# Altium schematic sheet 06 USB3_LED_OSC.SchDoc
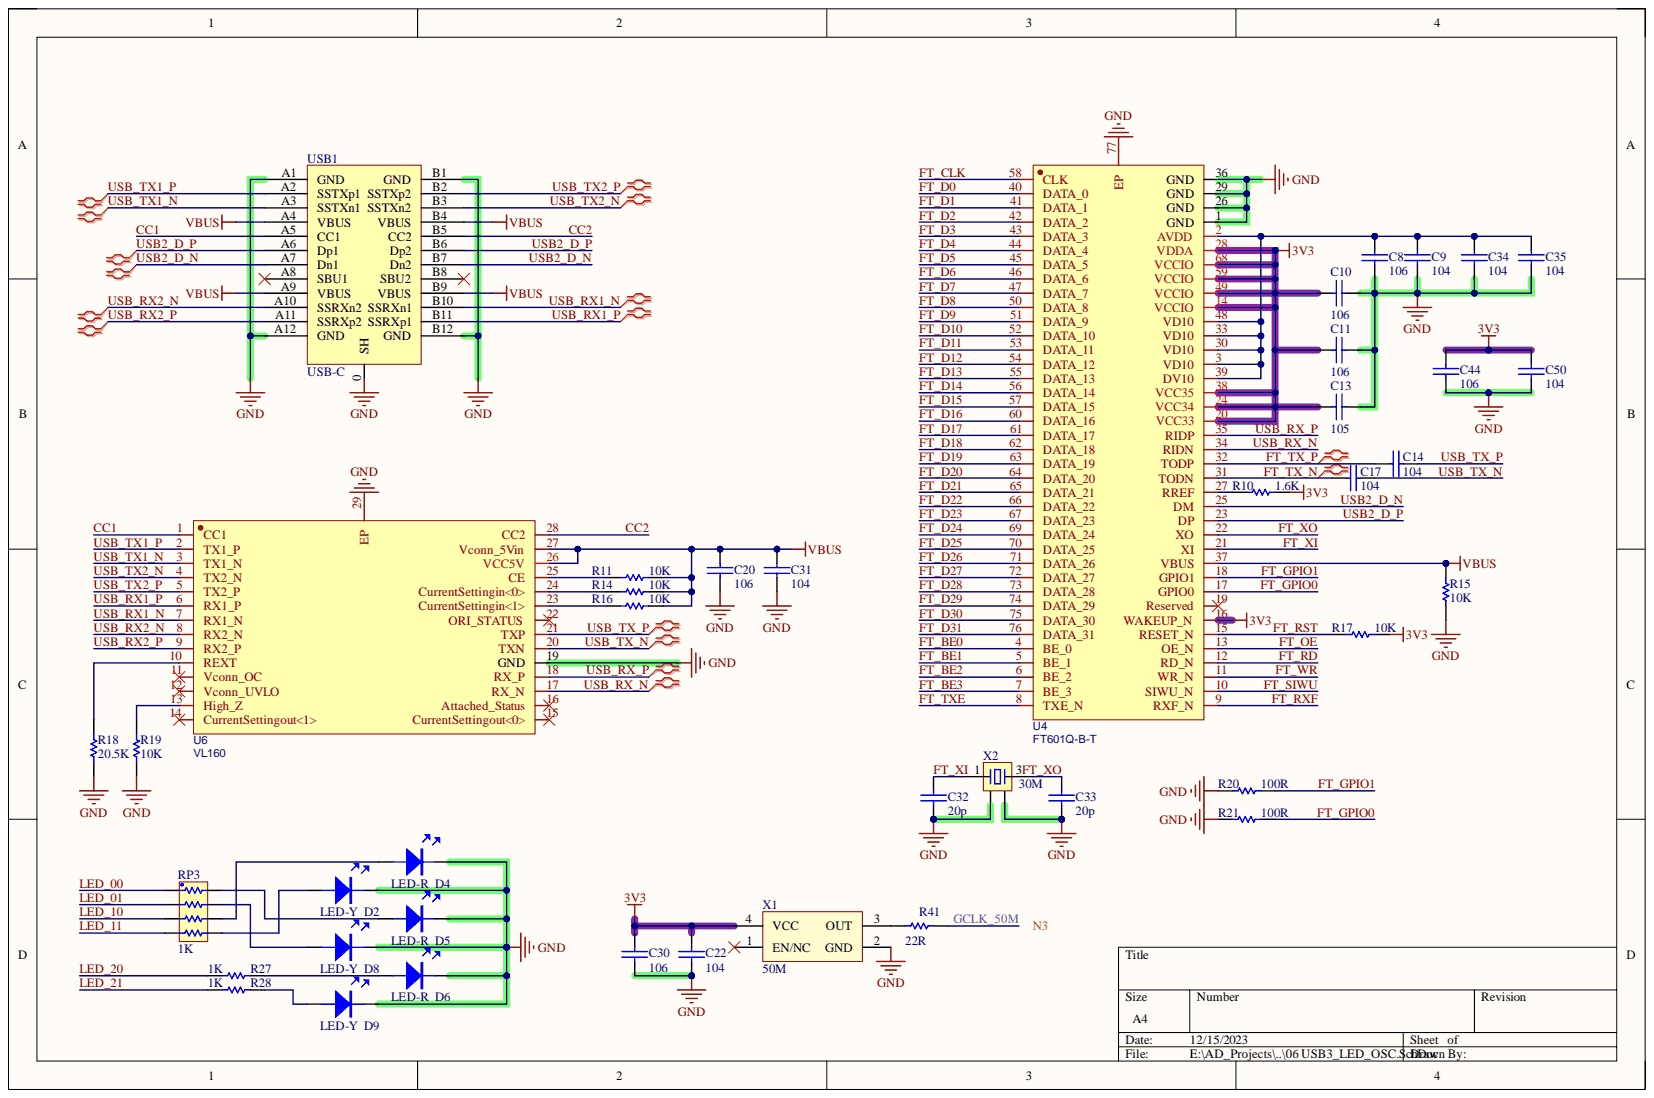
[diagram p0: 06 USB3_LED_OSC.SchDoc]

## Parts
C8  106  [0402C]
C9  104  [0402C]
C10  106  [0402C]
C11  106  [0402C]
C13  105  [0402C]
C14  104  [0402C]
C17  104  [0402C]
C20  106  [0402C]
C22  104  [0402C]
C30  106  [0402C]
C31  104  [0402C]
C32  20p  [0402C]
C33  20p  [0402C]
C34  104  [0402C]
C35  104  [0402C]
C44  106  [0402C]
C50  104  [0402C]
D2  LED-Y  [LED-0603Y]
D4  LED-R  [LED-0603W]
D5  LED-R  [LED-0603W]
D6  LED-R  [LED-0603W]
D8  LED-Y  [LED-0603Y]
D9  LED-Y  [LED-0603Y]
R10  1.6K  [0402R]
R11  10K  [0402R]
R14  10K  [0402R]
R15  10K  [0402R]
R16  10K  [0402R]
R17  10K  [0402R]
R18  20.5K  [0402R]
R19  10K  [0402R]
R20  100R  [0402R]
R21  100R  [0402R]
R27  1K  [0402R]
R28  1K  [0402R]
R41  22R  [0402R]
RP3  1K  [贴片排阻]
U4  FT601Q-B-T  [QFN-76_L9.0-W9.0-P0.40-TL-EP]
U6  VL160  [MQFN-28_L4.5-W3.5-P0.40-BL-EP]
USB1  USB-C  [USBC_24P_侧立]
X1  50M  [OSC 3225-4P]
X2  30M  [OSC 3225-4P]

## Nets
3V3: C10.2 C11.2 C13.2 C22.2 C30.2 C44.2 C50.2 R10.2 R17.2 U4.14(VCCIO) U4.16(WAKEUP_N) U4.20(VCC33) U4.24(VCC34) U4.28(VDDA) U4.38(VCC35) U4.49(VCCIO) U4.59(VCCIO) U4.68(VCCIO) X1.4(VCC)
GND: C8.1 C9.1 C10.1 C11.1 C13.1 C20.1 C22.1 C30.1 C31.1 C32.1 C33.1 C34.1 C35.1 C44.1 C50.1 D2.K D4.K D5.K D6.K D8.K D9.K R15.1 R18.2 R19.2 R20.1 R21.1 U4.1(GND) U4.26(GND) U4.29(GND) U4.36(GND) U4.77(EP) U6.19(GND) U6.29(EP) USB1.0(SH) USB1.A1(GND) USB1.A12(GND) USB1.B1(GND) USB1.B12(GND) X1.2(GND) X2.2 X2.4
VBUS: C20.2 C31.2 R11.2 R14.2 R15.2 R16.2 U4.37(VBUS) U6.26(VCC5V) U6.27(Vconn_5Vin) USB1.A4(VBUS) USB1.A9(VBUS) USB1.B4(VBUS) USB1.B9(VBUS)
CC1: U6.1(CC1) USB1.A5(CC1)
CC2: U6.28(CC2) USB1.B5(CC2)
FT_BE0: U4.4(BE_0)
FT_BE1: U4.5(BE_1)
FT_BE2: U4.6(BE_2)
FT_BE3: U4.7(BE_3)
FT_CLK: U4.58(CLK)
FT_D0: U4.40(DATA_0)
FT_D1: U4.41(DATA_1)
FT_D2: U4.42(DATA_2)
FT_D3: U4.43(DATA_3)
FT_D4: U4.44(DATA_4)
FT_D5: U4.45(DATA_5)
FT_D6: U4.46(DATA_6)
FT_D7: U4.47(DATA_7)
FT_D8: U4.50(DATA_8)
FT_D9: U4.51(DATA_9)
FT_D10: U4.52(DATA_10)
FT_D11: U4.53(DATA_11)
FT_D12: U4.54(DATA_12)
FT_D13: U4.55(DATA_13)
FT_D14: U4.56(DATA_14)
FT_D15: U4.57(DATA_15)
FT_D16: U4.60(DATA_16)
FT_D17: U4.61(DATA_17)
FT_D18: U4.62(DATA_18)
FT_D19: U4.63(DATA_19)
FT_D20: U4.64(DATA_20)
FT_D21: U4.65(DATA_21)
FT_D22: U4.66(DATA_22)
FT_D23: U4.67(DATA_23)
FT_D24: U4.69(DATA_24)
FT_D25: U4.70(DATA_25)
FT_D26: U4.71(DATA_26)
FT_D27: U4.72(DATA_27)
FT_D28: U4.73(DATA_28)
FT_D29: U4.74(DATA_29)
FT_D30: U4.75(DATA_30)
FT_D31: U4.76(DATA_31)
FT_GPIO0: R21.2 U4.17(GPIO0)
FT_GPIO1: R20.2 U4.18(GPIO1)
FT_OE: U4.13(OE_N)
FT_RD: U4.12(RD_N)
FT_RST: R17.1 U4.15(RESET_N)
FT_RXF: U4.9(RXF_N)
FT_SIWU: U4.10(SIWU_N)
FT_TX_N: C17.1 U4.31(TODN)
FT_TX_P: C14.1 U4.32(TODP)
FT_TXE: U4.8(TXE_N)
FT_WR: U4.11(WR_N)
FT_XI: C32.2 U4.21(XI) X2.1
FT_XO: C33.2 U4.22(XO) X2.3
GCLK_50M: R41.1
LED_00: RP3.1
LED_01: RP3.2
LED_10: RP3.3
LED_11: RP3.4
LED_20: R27.2
LED_21: R28.2
USB2_D_N: U4.25(DM) USB1.A7(Dn1) USB1.B7(Dn2)
USB2_D_P: U4.23(DP) USB1.A6(Dp1) USB1.B6(Dp2)
USB_RX1_N: U6.7(RX1_N) USB1.B10(SSRXn1)
USB_RX1_P: U6.6(RX1_P) USB1.B11(SSRXp1)
USB_RX2_N: U6.8(RX2_N) USB1.A10(SSRXn2)
USB_RX2_P: U6.9(RX2_P) USB1.A11(SSRXp2)
USB_RX_N: U4.34(RIDN) U6.17(RX_N)
USB_RX_P: U4.35(RIDP) U6.18(RX_P)
USB_TX1_N: U6.3(TX1_N) USB1.A3(SSTXn1)
USB_TX1_P: U6.2(TX1_P) USB1.A2(SSTXp1)
USB_TX2_N: U6.4(TX2_N) USB1.B3(SSTXn2)
USB_TX2_P: U6.5(TX2_P) USB1.B2(SSTXp2)
USB_TX_N: C17.2 U6.20(TXN)
USB_TX_P: C14.2 U6.21(TXP)
NetC8_2: C8.2 C9.2 C34.2 C35.2 U4.2(AVDD) U4.3(VD10) U4.30(VD10) U4.33(VD10) U4.39(DV10) U4.48(VD10)
NetD2_A: D2.A RP3.5
NetD4_A: D4.A RP3.6
NetD5_A: D5.A RP3.8
NetD6_A: D6.A R27.1
NetD8_A: D8.A RP3.7
NetD9_A: D9.A R28.1
NetR10_1: R10.1 U4.27(RREF)
NetR11_1: R11.1 U6.25(CE)
NetR14_1: R14.1 U6.24(CurrentSettingin<0>)
NetR16_1: R16.1 U6.23(CurrentSettingin<1>)
NetR18_1: R18.1 U6.10(REXT)
NetR19_1: R19.1 U6.13(High_Z)
NetR41_2: R41.2 X1.3(OUT)

Not connected: U4 19(Reserved); U6 11(Vconn_OC) 12(Vconn_UVLO) 14(CurrentSettingout<1>) 15(CurrentSettingout<0>) 16(Attached_Status) 22(ORI_STATUS); USB1 A8(SBU1) B8(SBU2); X1 1(EN/NC)

## Library data of the parts
C8-C11 C13 C14 C17 C20 C22 C30-C35 C44 C50 = CAP: 无极性电容; Component Kind: Standard; Library Name: 电容.IntLib
D2 D4-D6 D8 D9 = LED: Component Kind: Standard; Library Name: LED.IntLib
R10 R11 R14-R21 R27 R28 R41 = RES: Component Kind: Standard; Library Name: 电阻.IntLib
RP3 = SMT_RES_PACK8: Component Kind: Standard; Library Name: 电阻.IntLib
U4 = FT601Q-B-T: Manufacturer: FTDI(飞特帝亚); Contributor: LCSC; Component Kind: Standard; JLCPCB Part Class: Extended Part; Library Name: 未分类IC.IntLib; Manufacturer Part: FT601Q-B-T; spicePre: U; spiceSymbolName: FT601Q-B-T; Supplier: LCSC; Supplier Part: C1550513
U6 = VL160: Manufacturer: null; Contributor: LCSC; Component Kind: Standard; JLCPCB Part Class: Extended Part; Library Name: 未分类IC.IntLib; Manufacturer Part: VL160; spicePre: U; spiceSymbolName: VL160; Supplier: LCSC; Supplier Part: C2841472
USB1 = USB-C/S: USB-C型母接口; 版权归属: 源创客; BOM名称: USB-C型母接口; Component Kind: Standard; Library Name: USB.IntLib; 学习资源: www.ooocke.com
X1 = XTAL-ACT-4P: 4脚有源晶振; Component Kind: Standard; Library Name: 晶振.IntLib
X2 = XTAL-4P: 4脚无源晶振; Component Kind: Standard; Library Name: 晶振.IntLib
